# Altium schematic sheet SD_CARD_WiFi.SchDoc
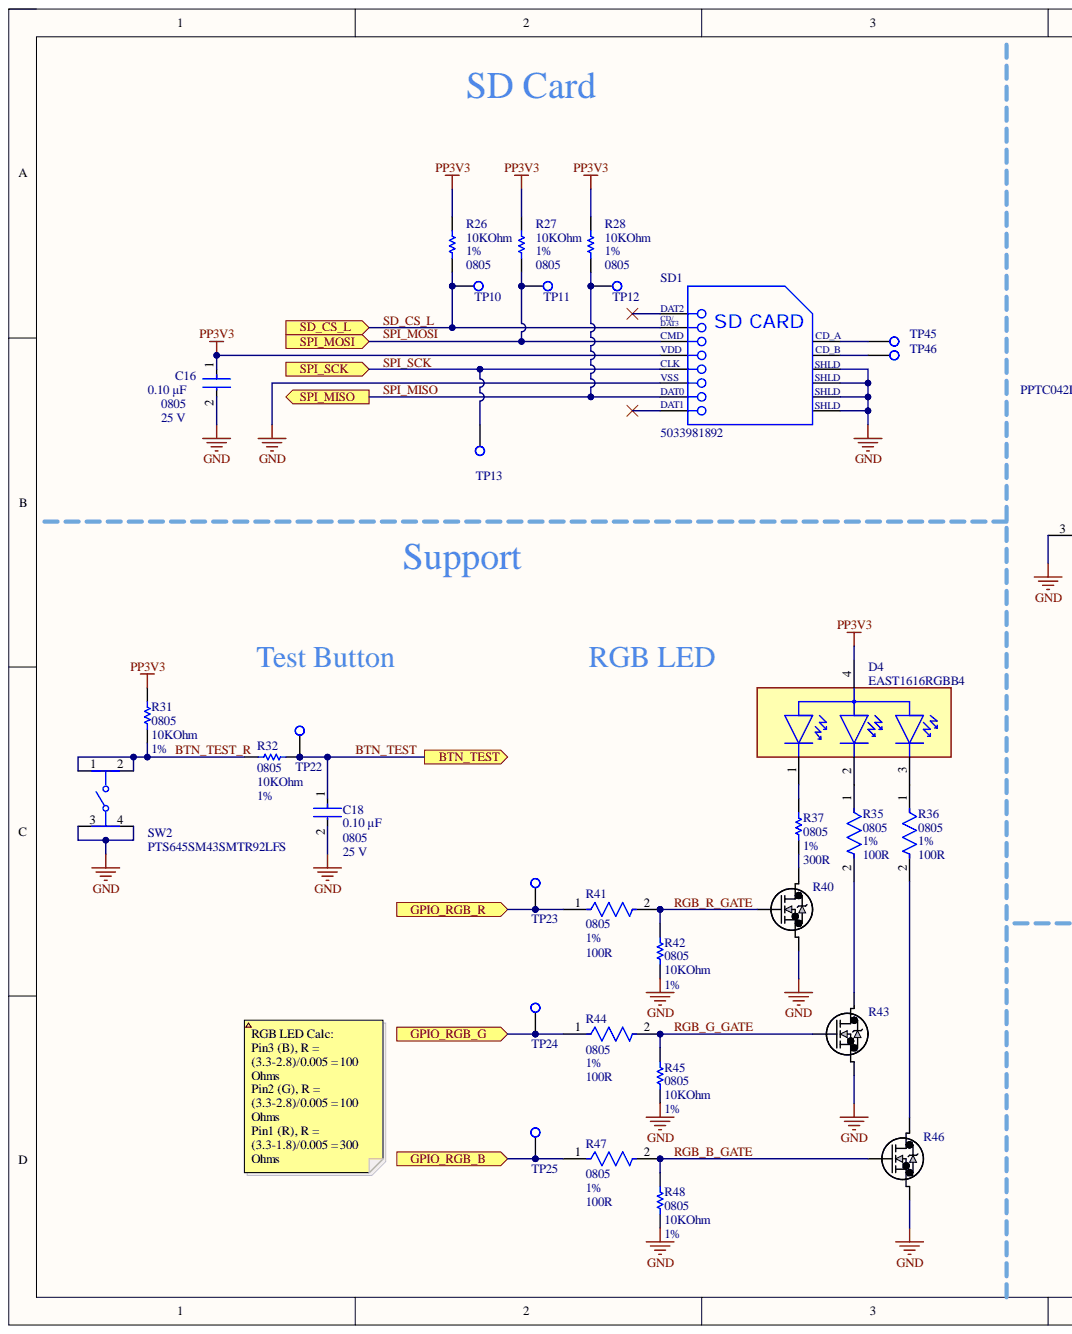
[diagram p0: SD_CARD_WiFi.SchDoc, left]
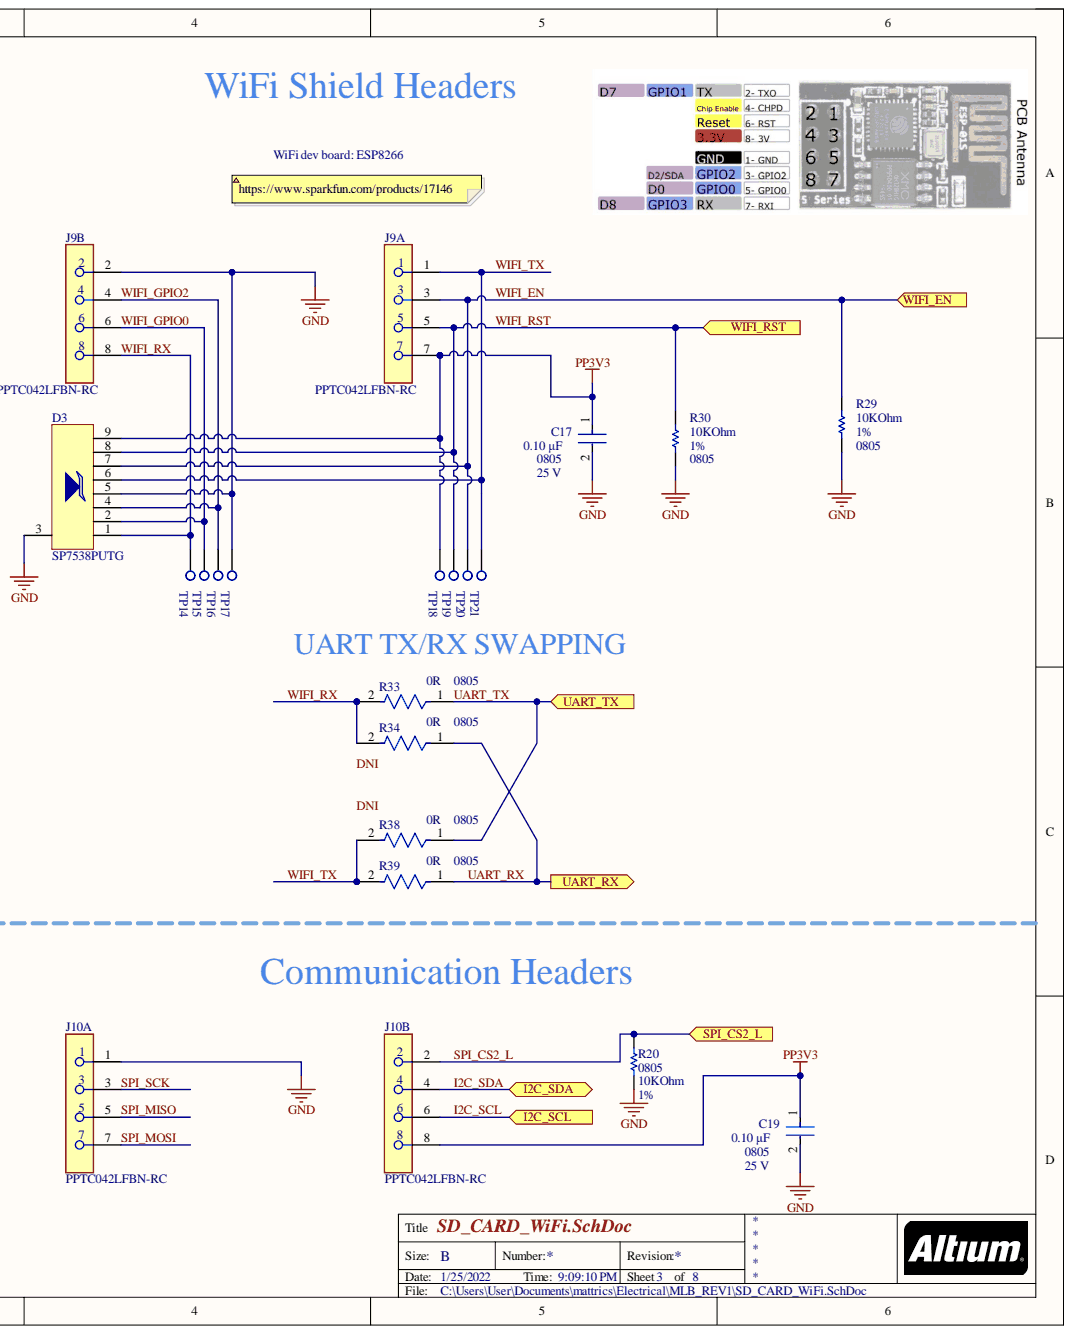
[diagram p1: SD_CARD_WiFi.SchDoc, right]

## Parts
C16  value 0.10 μF  Capacitance: 0.10 μF  Package: 0805  Voltage Rating: 25 V  [FP-C0805C-DN-MFG]  (hidden comment: C0805C104M3RACTU)
C17  value 0.10 μF  Package: 0805  Voltage Rating: 25 V  [FP-C0805C-DN-MFG]  (hidden comment: C0805C104M3RACTU)
C18  value 0.10 μF  Capacitance: 0.10 μF  Package: 0805  Voltage Rating: 25 V  [FP-C0805C-DN-MFG]  (hidden comment: C0805C104M3RACTU)
C19  value 0.10 μF  Package: 0805  Voltage Rating: 25 V  [FP-C0805C-DN-MFG]  (hidden comment: C0805C104M3RACTU)
D3  SP7538PUTG  [SP7538PUTG]
D4  EAST1616RGBB4  [FP-EAST1616RGBB4-MFG]
J9  PPTC042LFBN-RC  [FP-PPTC042LFBN-RC-MFG]  (parts A,B)
J10  PPTC042LFBN-RC  [FP-PPTC042LFBN-RC-MFG]  (parts A,B)
R20  value 10KOhm  Package Reference: 0805  Tolerance: 1%  [RESC2013X50X30NL20T20]  (hidden comment: CRCW080515K0FKTA)
R26  value 10KOhm  PackageReference: 0805  Tolerance: 1%  [RESC2013X60X35NL10T20]  (hidden comment: RC0805FR-0710KL)
R27  value 10KOhm  PackageReference: 0805  Tolerance: 1%  [RESC2013X60X35NL10T20]  (hidden comment: RC0805FR-0710KL)
R28  value 10KOhm  PackageReference: 0805  Tolerance: 1%  [RESC2013X60X35NL10T20]  (hidden comment: RC0805FR-0710KL)
R29  value 10KOhm  PackageReference: 0805  Tolerance: 1%  [RESC2013X60X35NL10T20]  (hidden comment: RC0805FR-0710KL)
R30  value 10KOhm  PackageReference: 0805  Tolerance: 1%  [RESC2013X60X35NL10T20]  (hidden comment: RC0805FR-0710KL)
R31  value 10KOhm  PackageReference: 0805  Tolerance: 1%  [RESC2013X60X35NL10T20]  (hidden comment: RC0805FR-0710KL)
R32  value 10KOhm  Package Reference: 0805  Tolerance: 1%  [RESC2013X50X30NL20T20]  (hidden comment: CRCW080515K0FKTA)
R33  Case Code (Imperial): 0805  Resistance: 0R  [FP-RMCF0805-MFG]  (hidden comment: RMCF0805ZT0R00)
R34  Case Code (Imperial): 0805  Resistance: 0R  [FP-RMCF0805-MFG]  (hidden comment: RMCF0805ZT0R00)
R35  Case Code (Imperial): 0805  Resistance: 100R  Tolerance: 1%  [FP-RMCF0805-IPC_A]  (hidden comment: RMCF0805FT100R)
R36  Case Code (Imperial): 0805  Resistance: 100R  Tolerance: 1%  [FP-RMCF0805-IPC_A]  (hidden comment: RMCF0805FT100R)
R37  PackageReference: 0805  Resistance: 300R  Tolerance: 1%  [RESC2013X70X40LL20T20]  (hidden comment: ERJ-6ENF3000V)
R38  Case Code (Imperial): 0805  Resistance: 0R  [FP-RMCF0805-MFG]  (hidden comment: RMCF0805ZT0R00)
R39  Case Code (Imperial): 0805  Resistance: 0R  [FP-RMCF0805-MFG]  (hidden comment: RMCF0805ZT0R00)
R40  RK7002BMT116  [TRANS_RK7002BMHZG_ROM]  (value not drawn)
R41  Case Code (Imperial): 0805  Resistance: 100R  Tolerance: 1%  [FP-RMCF0805-IPC_A]  (hidden comment: RMCF0805FT100R)
R42  value 10KOhm  Package Reference: 0805  Tolerance: 1%  [RESC2013X50X30NL20T20]  (hidden comment: CRCW080515K0FKTA)
R43  RK7002BMT116  [TRANS_RK7002BMHZG_ROM]  (value not drawn)
R44  Case Code (Imperial): 0805  Resistance: 100R  Tolerance: 1%  [FP-RMCF0805-IPC_A]  (hidden comment: RMCF0805FT100R)
R45  value 10KOhm  Package Reference: 0805  Tolerance: 1%  [RESC2013X50X30NL20T20]  (hidden comment: CRCW080515K0FKTA)
R46  RK7002BMT116  [TRANS_RK7002BMHZG_ROM]  (value not drawn)
R47  Case Code (Imperial): 0805  Resistance: 100R  Tolerance: 1%  [FP-RMCF0805-IPC_A]  (hidden comment: RMCF0805FT100R)
R48  value 10KOhm  Package Reference: 0805  Tolerance: 1%  [RESC2013X50X30NL20T20]  (hidden comment: CRCW080515K0FKTA)
SD1  5033981892  [MOLX-503398-1892_V]
SW2  PTS645SM43SMTR92LFS  [FP-PTS645SM43SMTR92LFS-MFG]
TP10  RCU-0C  [TP+195X110]  (value not drawn)
TP11  RCU-0C  [TP+195X110]  (value not drawn)
TP12  RCU-0C  [TP+195X110]  (value not drawn)
TP13  RCU-0C  [TP+195X110]  (value not drawn)
TP14  RCU-0C  [TP+195X110]  (value not drawn)
TP15  RCU-0C  [TP+195X110]  (value not drawn)
TP16  RCU-0C  [TP+195X110]  (value not drawn)
TP17  RCU-0C  [TP+195X110]  (value not drawn)
TP18  RCU-0C  [TP+195X110]  (value not drawn)
TP19  RCU-0C  [TP+195X110]  (value not drawn)
TP20  RCU-0C  [TP+195X110]  (value not drawn)
TP21  RCU-0C  [TP+195X110]  (value not drawn)
TP22  RCU-0C  [TP+195X110]  (value not drawn)
TP23  RCU-0C  [TP+195X110]  (value not drawn)
TP24  RCU-0C  [TP+195X110]  (value not drawn)
TP25  RCU-0C  [TP+195X110]  (value not drawn)
TP45  RCU-0C  [TP+195X110]  (value not drawn)
TP46  RCU-0C  [TP+195X110]  (value not drawn)

## Ports
BTN_TEST, GPIO_RGB_B, GPIO_RGB_G, GPIO_RGB_R, I2C_SCL, I2C_SDA, SD_CS_L, SPI_CS2_L, SPI_MISO, SPI_MOSI, SPI_SCK, UART_RX, UART_TX, WIFI_EN, WIFI_RST

## Nets
GND: C16.2 C17.2 C18.2 C19.2 D3.3 D3.5 J9.2 J10.1 R20.1 R29.1 R30.1 R40.3 R42.1 R43.3 R45.1 R46.3 R48.1 SD1.6 SD1.11 SD1.12 SD1.13 SD1.14 SW2.3 SW2.4 TP17.1
PP3V3: C16.1 C17.1 C19.1 D3.9 D4.4 J9.7 J10.8 R26.2 R27.2 R28.2 R31.2 SD1.4 TP18.1
BTN_TEST: C18.1 R32.2 TP22.1 ; port BTN_TEST
BTN_TEST_R: R31.1 R32.1 SW2.1 SW2.2
GPIO_RGB_B: R47.1 TP25.1 ; port GPIO_RGB_B
GPIO_RGB_G: R44.1 TP24.1 ; port GPIO_RGB_G
GPIO_RGB_R: R41.1 TP23.1 ; port GPIO_RGB_R
I2C_SCL: J10.6 ; port I2C_SCL
I2C_SDA: J10.4 ; port I2C_SDA
RGB_B_GATE: R46.2 R47.2 R48.2
RGB_G_GATE: R43.2 R44.2 R45.2
RGB_R_GATE: R40.2 R41.2 R42.2
SD_CS_L: R26.1 SD1.2(SD_CARD) TP10.1 ; port SD_CS_L
SPI_CS2_L: J10.2 R20.2 ; port SPI_CS2_L
SPI_MISO: J10.5 R28.1 SD1.7 TP12.1 ; port SPI_MISO
SPI_MOSI: J10.7 R27.1 SD1.3 TP11.1 ; port SPI_MOSI
SPI_SCK: J10.3 SD1.5 TP13.1 ; port SPI_SCK
UART_RX: R34.1 R39.1 ; port UART_RX
UART_TX: R33.1 R38.1 ; port UART_TX
WIFI_EN: D3.7 J9.3 R29.2 TP20.1 ; port WIFI_EN
WIFI_GPIO0: D3.2 J9.6 TP15.1
WIFI_GPIO2: D3.4 J9.4 TP16.1
WIFI_RST: D3.8 J9.5 R30.2 TP19.1 ; port WIFI_RST
WIFI_RX: D3.1 J9.8 R33.2 R34.2 TP14.1
WIFI_TX: D3.6 J9.1 R38.2 R39.2 TP21.1
NetD4_1: D4.1 R37.1
NetD4_2: D4.2 R35.1
NetD4_3: D4.3 R36.1
NetR35_2: R35.2 R43.1
NetR36_2: R36.2 R46.1
NetR37_2: R37.2 R40.1
NetSD1_9: SD1.9 TP45.1
NetSD1_10: SD1.10 TP46.1

Not connected: SD1 1 8

## Library data of the parts
C16-C19 = CMP-2007-00282-2: CAP CER 0.1UF 25V 20% X7R 0805; Manufacturer: KEMET; Material: Ceramic; Temperature Coefficient: 30ppm/°C; Tolerance: 0.2; Automotive: No; Category: Cap; Component Kind: Standard; ComponentLink2Description: Datasheet; ComponentLink2URL: https://content.kemet.com/datasheets/KEM_C1003_C0G_SMD.pdf; Device Class L1: Passive Components; Device Class L2: Capacitors; Device Class L3: Ceramic Capacitors; Digikey Description: CAP CER 0.1UF 25V 20% X7R 0805; Height: 0.88mm; Ipc Land Pattern Name: CAPC200125X78; Lead Free: Yes; Library Name: Altium Content Vault; Manufacturer Part Number: C0805C104M3RACTU; Mouser Description: Multilayer Ceramic Capacitors MLCC - SMD/SMT 25volts 0.1uF X7R 20%; Octopart: https://octopart.com/c0805c104m3ractu-kemet-9138612; RoHS: TRUE; Supplier: Digi-Key; Supplier Part Number: 399-C0805C104M3RAC7800CT-ND; Temperature: -55°C to +125°C; Temperature Characteristic: X7R; Temperature Range High: +125°C; Temperature Range Low: -55°C
  C17 C19: Capacitance: 0.10 μF
D3 = SP7538PUTG: ESD Suppressor TVS Octal Uni-Dir 25kV 9-Pin UDFN T/R; Manufacturer: Littelfuse; Clamping Voltage: 7V; Number of Unidirectional Channels: 8; Peak Pulse Current: 2A; Power Line Protection: Yes; Reverse Standoff Voltage: 5V; Category: TVS Diodes; Component Kind: Standard; ComponentLink1Description: http://www.littelfuse.com/~/media/electronics/datasheets/tvs_diode_arrays/littelfuse_tvs_diode_array_sp7538p_datasheet.pdf.pdf?src-supplier=Farnell; ComponentLink1URL: http://www.littelfuse.com/~/media/electronics/datasheets/tvs_diode_arrays/littelfuse_tvs_diode_array_sp7538p_datasheet.pdf.pdf?src-supplier=Farnell; ComponentLink2Description: http://www.littelfuse.com/~/media/electronics/datasheets/tvs_diode_arrays/littelfuse_tvs_diode_array_sp7538p_datasheet.pdf.pdf?src-supplier=Digi-Key; ComponentLink2URL: http://www.littelfuse.com/~/media/electronics/datasheets/tvs_diode_arrays/littelfuse_tvs_diode_array_sp7538p_datasheet.pdf.pdf?src-supplier=Digi-Key; ComponentLink3Description: https://www.littelfuse.com/~/media/electronics/datasheets/tvs_diode_arrays/littelfuse_tvs_diode_array_sp7538p_datasheet.pdf.pdf?src-supplier=Digi-Key; ComponentLink3URL: https://www.littelfuse.com/~/media/electronics/datasheets/tvs_diode_arrays/littelfuse_tvs_diode_array_sp7538p_datasheet.pdf.pdf?src-supplier=Digi-Key; ComponentLink4Description: http://www.littelfuse.com/~/media/electronics/datasheets/tvs_diode_arrays/littelfuse_tvs_diode_array_sp7538p_datasheet.pdf.pdf?src-supplier=Arrow+Electronics; ComponentLink4URL: http://www.littelfuse.com/~/media/electronics/datasheets/tvs_diode_arrays/littelfuse_tvs_diode_array_sp7538p_datasheet.pdf.pdf?src-supplier=Arrow+Electronics; ComponentLink5Description: http://www.littelfuse.com/~/media/electronics/datasheets/tvs_diode_arrays/littelfuse_tvs_diode_array_sp7538p_datasheet.pdf.pdf?src-supplier=Mouser; ComponentLink5URL: http://www.littelfuse.com/~/media/electronics/datasheets/tvs_diode_arrays/littelfuse_tvs_diode_array_sp7538p_datasheet.pdf.pdf?src-supplier=Mouser; ComponentLink6Description: http://www.littelfuse.com/~/media/electronics/datasheets/tvs_diode_arrays/littelfuse_tvs_diode_array_sp7538p_datasheet.pdf.pdf?src-supplier=Verical; ComponentLink6URL: http://www.littelfuse.com/~/media/electronics/datasheets/tvs_diode_arrays/littelfuse_tvs_diode_array_sp7538p_datasheet.pdf.pdf?src-supplier=Verical; ComponentLink7Description: http://www.littelfuse.com/~/media/electronics/datasheets/tvs_diode_arrays/littelfuse_tvs_diode_array_sp7538p_datasheet.pdf.pdf?src-supplier=Newark; ComponentLink7URL: http://www.littelfuse.com/~/media/electronics/datasheets/tvs_diode_arrays/littelfuse_tvs_diode_array_sp7538p_datasheet.pdf.pdf?src-supplier=Newark; ComponentLink8Description: http://www.littelfuse.com/~/media/electronics/datasheets/tvs_diode_arrays/littelfuse_tvs_diode_array_sp7538p_datasheet.pdf.pdf?src-supplier=TTI; ComponentLink8URL: http://www.littelfuse.com/~/media/electronics/datasheets/tvs_diode_arrays/littelfuse_tvs_diode_array_sp7538p_datasheet.pdf.pdf?src-supplier=TTI; Library Name: MLBSchLib.SchLib; Manufacturer Part Number: SP7538PUTG; Max Operating Temperature: 150°C; Min Operating Temperature: -40°C; Mount: Surface Mount; Number of Pins: 9; Packaging: Cut Tape; Pricing 1: 1=0.95000, 10=0.85200, 100=0.66410, 500=0.54862, 1000=0.43838 (USD); RoHS: Yes; RoHS Compliant: Yes; Stock 1: 40273; Supplier: Digi-Key; Supplier Part Number: F7417CT-ND
D4 = EAST1616RGBB4: LED RGB CLEAR 4SMD; Manufacturer: Everlight Electronics Co Ltd; Color: Blue; Element Configuration: Common Anode; Forward Voltage: 2.8V; Lens Color: Colorless; Lens Size: 1.1mm; Lens Style: Flat; Test Current: 5mA; Viewing Angle: 120°; Case Code (Imperial): 0606; Case Code (Metric): 1616; Case/Package: 0606; Component Kind: Standard; Height: 0.45mm; Length: 1.6mm; Lens Transparency: Clear; Library Name: MLBSchLib.SchLib; Manufacturer Part Number: EAST1616RGBB4; Mount: Surface Mount; Packaging: Tape and Reel; Supplier: Digi-Key; Supplier Part Number: 1080-1552-1-ND; Width: 1.6mm
J9 J10 = PPTC042LFBN-RC: CONN HDR 8POS 0.1 TIN PCB; Manufacturer: Sullins Connector Solutions; Conn Gender: Female; Conn Orientation: Straight; Current Rating: 3A; Is Female: yes; Number Of Contacts: 8; Pitch: 2.54mm; Voltage Rating: 250V; Automotive: No; Category: Conn; Component Kind: Standard; ComponentLink2Description: Datasheet; ComponentLink2URL: https://drawings-pdf.s3.amazonaws.com/10492.pdf; Contact Material: Tin,Nickel; Device Class L1: Connectors; Device Class L2: Headers and Wire Housings; Device Class L3: unset; Digikey Description: CONN HDR 8POS 0.1 TIN PCB; Height: 8.65mm; Lead Free: Yes; Library Name: MLBSchLib.SchLib; Manufacturer Part Number: PPTC042LFBN-RC; Number Of Rows: 2; Octopart: https://octopart.com/pptc042lfbn-rc-sullins-271088; Package: PPTC042LFBN-RC; RoHS: TRUE; Supplier: Digi-Key; Supplier Part Number: S7072-ND; Temperature: -40°C to +105°C; Temperature Range High: +105°C; Temperature Range Low: -40°C
R20 R42 R45 R48 = CMP-2001-04968-1: Manufacturer: Vishay Dale; Component Kind: Standard; Library Name: Altium Content Vault; Manufacturer Part Number: CRCW080515K0FKTA; Supplier: Digi-Key; Supplier Part Number: 541-3019-1-ND
R26-R31 = CMP-1659-00013-4: Chip Resistor, 10 KOhm, +/- 1%, 0.125 W, -55 to 155 degC, 0805 (2012 Metric), RoHS, Tape and Reel; Manufacturer: Yageo; Voltage Rating: 150 V; Component Kind: Standard; ComponentLink1Description: Manufacturer URL; ComponentLink1URL: http://www.yageo.com/; ComponentLink2Description: Datasheet; ComponentLink2URL: http://www.yageo.com/documents/recent/PYu-RC_Group_51_RoHS_L_04.pdf; DatasheetVersion: Rev. 4, 02/2016; Height: 0.5 mm; Length: 2 mm; Library Name: Altium Content Vault; Manufacturer Part Number: RC0805FR-0710KL; Max Operating Temperature: 155 degC; Min Operating Temperature: -55 degC; Mount: Surface Mount; Mounting Technology: Surface Mount; Package Quantity: 5000; PackageDescription: 2-Pin Surface Mounted Device, Body 2 x 1.25 mm; Packaging: Tape and Reel; Pins: 2; REACH SVHC: No SVHC; RoHSCompliant: true; Supplier: Digi-Key; Supplier Part Number: RC0805FR-0710KL
R32 = CMP-2001-04968-1: Manufacturer: Vishay Dale; Value: 10KOhm; Component Kind: Standard; Library Name: Altium Content Vault; Manufacturer Part Number: CRCW080515K0FKTA; Supplier: Digi-Key; Supplier Part Number: 541-3019-1-ND
R33 R34 R38 R39 = CMP-26527-000017-1: RES 0 OHM JUMPER 1/8W 0805; Manufacturer: Stackpole Electronics Inc; Max Power Dissipation: 125mW; Case Code (Metric): 2012; Case/Package: 0805; Component Kind: Standard; Composition: Thick Film; Contact Plating: Tin; Depth: 1.25mm; Features: Automotive AEC-Q200; Height: 0.026inch; Lead Free: Lead Free; Length: 2mm; Library Name: Altium Content Vault; Manufacturer Part Number: RMCF0805ZT0R00; Max Operating Temperature: 155°C; Military Standard: Not; Min Operating Temperature: -55°C; Mount: Surface Mount; Number of Terminations: 2; Packaging: Tape and Reel; Power Rating: 125mW; Radiation Hardening: No; Supplier: Digi-Key; Supplier Part Number: RMCF0805ZT0R00CT-ND; Width: 0.049inch
R35 R36 R41 R44 R47 = CMP-26527-001731-1: 100Ω ±1% 0.125W 0805 Thick Film Chip Resistor AEC-Q200 compliant; Manufacturer: Stackpole Electronics Inc; Max Power Dissipation: 125mW; Power Rating: 250mW; Temperature Coefficient: 100ppm/°C; Case Code (Metric): 2012; Case/Package: 0805; Component Kind: Standard; Construction: Rectangular; Contact Plating: Tin; Depth: 1.25mm; Features: Automotive AEC-Q200; Height: 0.026inch; Lead Free: Lead Free; Length: 2mm; Library Name: Altium Content Vault; Manufacturer Part Number: RMCF0805FT100R; Max Operating Temperature: 155°C; Military Standard: Not; Min Operating Temperature: -55°C; Mount: Surface Mount; Number of Terminations: 2; Packaging: Tape and Reel; Radiation Hardening: No; Resistor Type: Thick Film; RoHS Compliant: Yes; Series: RMCF; Size Code: 0805; Supplier: Digi-Key; Supplier Part Number: RMCF0805FT100RCT-ND; Width: 0.049inch
R37 = CMP-2001-00110-5: Chip Resistor, 300 Ohm, +/- 1%, 0.125 W, -55 to 155 degC, 0805 (2012 Metric), RoHS, Tape and Reel; Manufacturer: Panasonic; Voltage Rating: 150 V; Component Kind: Standard; ComponentLink1Description: Manufacturer URL; ComponentLink1URL: http://www.panasonic.com/; ComponentLink2Description: Datasheet; ComponentLink2URL: http://industrial.panasonic.com/cdbs/www-data/pdf/RDA0000/AOA0000C86.pdf; DatasheetVersion: 04/2015; Height: 0.6 mm; Length: 2 mm; Library Name: Altium Content Vault; Manufacturer Part Number: ERJ-6ENF3000V; Max Operating Temperature: 155 degC; Min Operating Temperature: -55 degC; Mount: Surface Mount; Mounting Technology: Surface Mount; Package Quantity: 5000; PackageDescription: 2-Pin Surface Mount Device, Body 2 x 1.25 mm; Packaging: Tape and Reel; REACH SVHC: Yes; RoHSCompliant: true; Supplier: Digi-Key; Supplier Part Number: P300CCT-ND
R40 R43 R46 = RK7002BMT116: No Description Available; Manufacturer: Rohm Semiconductor; Component Kind: Standard; Digi-Key_Part_Number_1: RK7002BMT116CT-ND; Digi-Key_Part_Number_2: RK7002BMT116DKR-ND; Digi-Key_Part_Number_3: RK7002BMT116TR-ND; Library Name: MLBSchLib.SchLib; Manufacturer Part Number: RK7002BMT116; RefDes: RefDes; Supplier: Digi-Key; Supplier Part Number: RK7002BMT116CT-ND; Type: DEV; Copyright: Copyright (C) 2022 Ultra Librarian. All rights reserved.
SD1 = 5033981892: Micro SD Connector, Pitch 1.1 mm, 8 Position, Height 1.4 mm, -25 to 85 degC, RoHS, Tape and Reel; Brand: Molex; Current Rating: 500mA; Voltage Rating: 10VAC/DC; Component Kind: Standard; Datasheet URL: http://www.molex.com/pdm_docs/sd/5033981892_sd.pdf; Datasheet Version: Rev. E, 04/2006; Factory Pack Quantity: 2400; Library Name: MLBSchLib.SchLib; Manufacturer: Molex; Manufacturer Part Number: 5033981892; Manufacturer URL: http://www.molex.com/; Mounting Technology: SurfaceMount; Package Description: Micro SD Connector, Pitch 1.1 mm, 8 Position, Height 1.4 mm; Package Reference: 503398-1892; Packaging: Reel; Product Category: MemoryCardConnectors; RoHS: Details; Series: 503398; Supplier: Digi-Key; Supplier Part Number: WM11190CT-ND
SW2 = PTS645SM43SMTR92_LFS: SWITCH TACTILE SPST-NO 0.05A 12V; Manufacturer: C&K; Actuator Length: 0.85mm; Actuator Material: Nylon; Actuator Type: Standard; Contact Resistance: 100mR; Current Rating: 50mA; Illumination Type: Non-Illuminated; Mechanical Life: 100000Cycles; Operating Force: 160gf; Switch Function: Off-Mom; Throw Configuration: SPST; Case/Package: SMD/SMT; Color: Black; Component Kind: Standard; Contact Material: Silver; Contact Plating: Silver; Depth: 9mm; Lead Free: Lead Free; Length: 6mm; Library Name: MLBSchLib.SchLib; Manufacturer Part Number: PTS645SM43SMTR92 LFS; Max Current Rating: 50mA; Max Operating Temperature: 60°C; Max Voltage Rating (DC): 12V; Min Operating Temperature: -20°C; Mount: Surface Mount; Orientation: Straight; Package Quantity: 1; Packaging: Tape and Reel; REACH SVHC: No SVHC; Supplier: Digi-Key; Supplier Part Number: CKN9112CT-ND; Termination: Gull Wing; Voltage Rating (DC): 12V
TP10-TP25 TP45 TP46 = RCU-0C: Natural PC Test Point , Plating Surface Mount Mounting Type; Manufacturer: TE Connectivity AMP Connectors; Check_prices: https://www.snapeda.com/parts/RCU-0C/TE%20Connectivity/view-part/4791537/?ref=eda; Component Kind: Standard; Library Name: MLBSchLib.SchLib; Manufacturer Part Number: RCU-0C; Package: SON-6 TE Connectivity AMP Connectors; Purchase-URL: https://pricing.snapeda.com/search/part/RCU-0C/?ref=eda; SnapEDA_Link: https://www.snapeda.com/parts/RCU-0C/TE%20Connectivity/view-part/4791537/?ref=snap; Supplier: Digi-Key; Supplier Part Number: A106145CT-ND
